# Altium schematic sheet High Voltage.SchDoc("High Voltage")
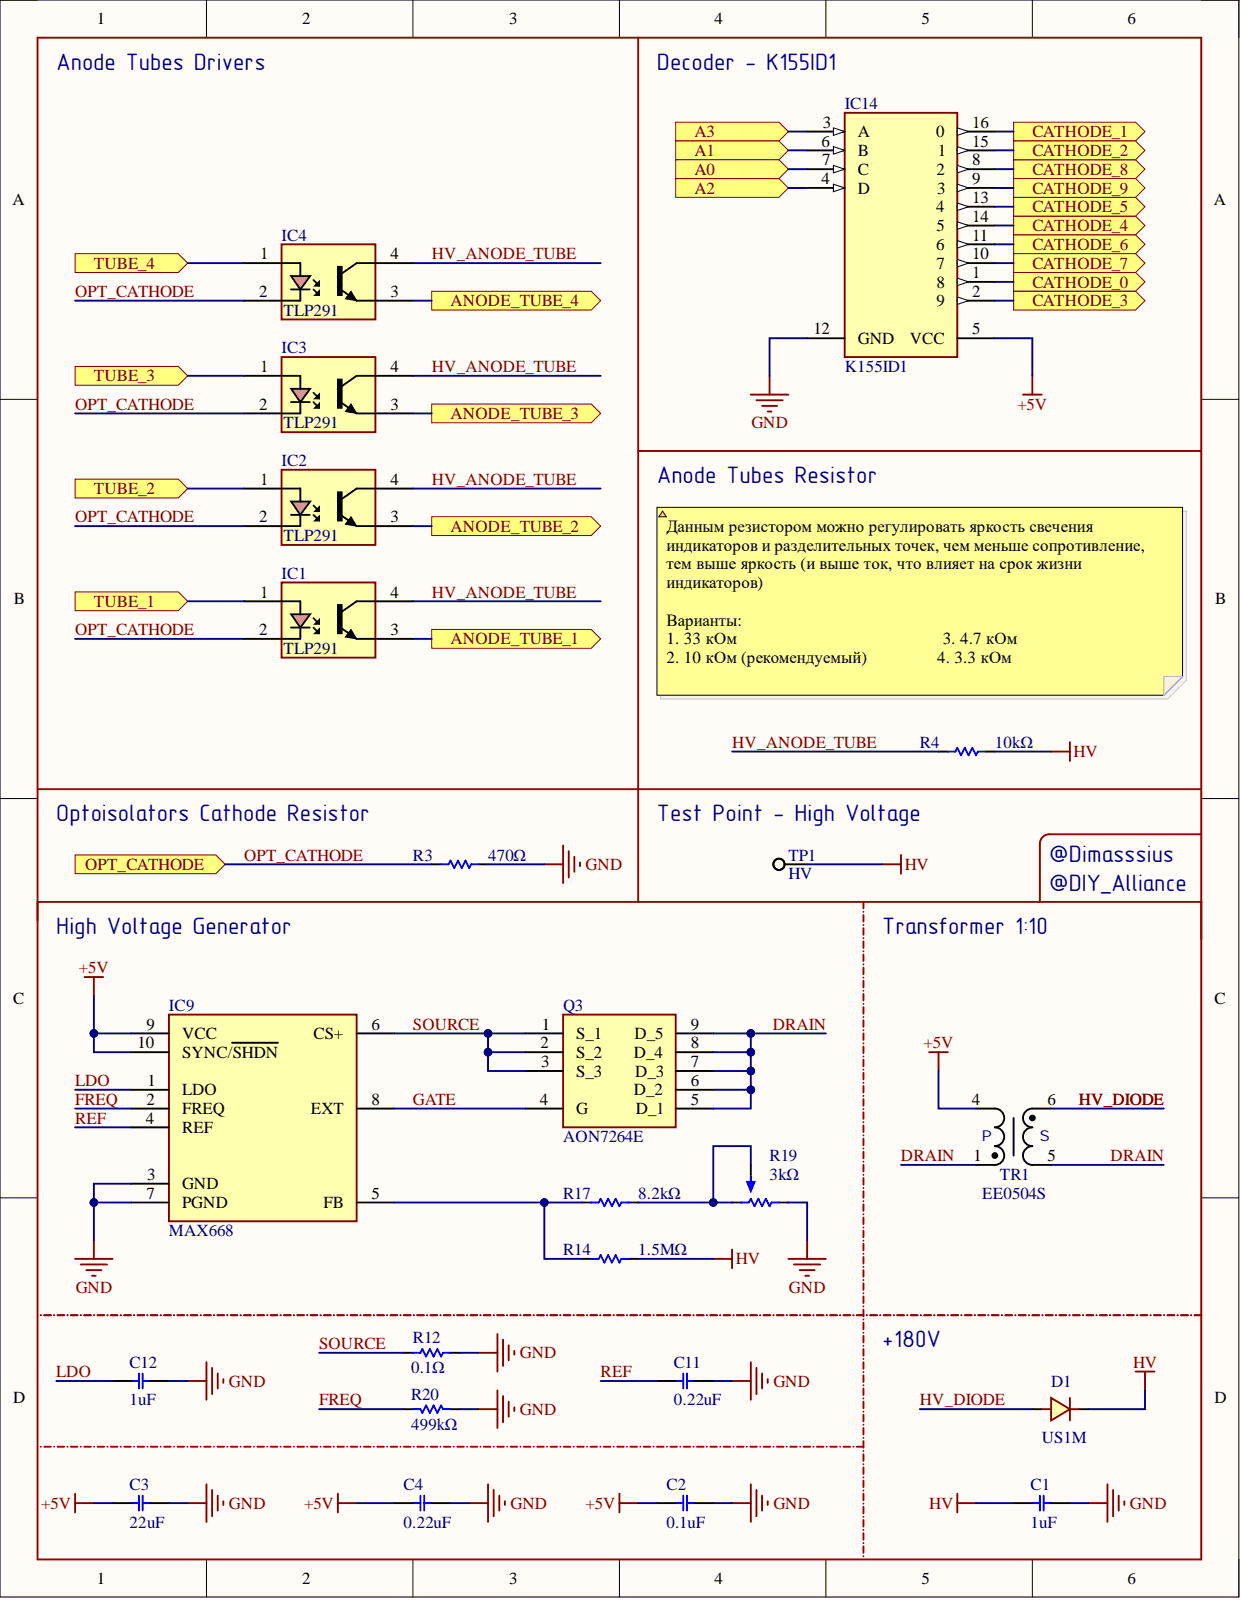
[diagram p0: High Voltage.SchDoc]

## Parts
C1  1uF  [CAP_SMD_MLCC_C1812_(M4532)]
C2  0.1uF  [CAP_SMD_MLCC_C0603_(M1608)_C0402A_(M1005A)]
C3  22uF  [CAP_SMD_MLCC_C0603_(M1608)]
C4  0.22uF  [CAP_SMD_MLCC_C0603_(M1608)_C0402A_(M1005A)]
C11  0.22uF  [CAP_SMD_MLCC_C0603_(M1608)_C0402A_(M1005A)]
C12  1uF  [CAP_SMD_MLCC_C0603_(M1608)_C0402A_(M1005A)]
D1  US1M  [DO-214AC]
IC1  TLP291  [SOIC127P700X230-4N]
IC2  TLP291  [SOIC127P700X230-4N]
IC3  TLP291  [SOIC127P700X230-4N]
IC4  TLP291  [SOIC127P700X230-4N]
IC9  MAX668  [SOP50P490X110-10N]
IC14  K155ID1  [DIP16]
Q3  AON7264E  [DFN-8-EP(3x3)]
R3  470Ω  [RES_SMD_R0603_(M1608)_R0402A_(M1005A)]
R4  10kΩ  [RES_SMD_R0603_(M1608)_R0402A_(M1005A)]
R12  0.1Ω  [RES_SMD_R1206_(M3216)]
R14  1.5MΩ  [RES_SMD_R0603_(M1608)_R0402A_(M1005A)]
R17  8.2kΩ  [RES_SMD_R0603_(M1608)_R0402A_(M1005A)]
R19  3kΩ  [TC33X]
R20  499kΩ  [RES_SMD_R0603_(M1608)_R0402A_(M1005A)]
TP1  HV  [Test Point]  (no BOM)
TR1  EE0504S  [EE0504S]

## Ports
A0, A1, A2, A3, ANODE_TUBE_1, ANODE_TUBE_2, ANODE_TUBE_3, ANODE_TUBE_4, CATHODE_0, CATHODE_1, CATHODE_2, CATHODE_3, CATHODE_4, CATHODE_5, CATHODE_6, CATHODE_7, CATHODE_8, CATHODE_9, OPT_CATHODE, TUBE_1, TUBE_2, TUBE_3, TUBE_4

## Nets
+5V: C2.1 C3.1 C4.2 IC9.9(VCC) IC9.10(SYNC/SHDN) IC14.5(VCC) TR1.4
GND: C1.1 C2.2 C3.2 C4.1 C11.1 C12.2 IC9.3(GND) IC9.7(PGND) IC14.12(GND) R3.1 R12.1 R19.3 R20.2
HV: C1.2 D1.1 R4.2 R14.1 TP1.1
A0: IC14.7(C) ; port A0
A1: IC14.6(B) ; port A1
A2: IC14.4(D) ; port A2
A3: IC14.3(A) ; port A3
ANODE_TUBE_1: IC1.3 ; port ANODE_TUBE_1
ANODE_TUBE_2: IC2.3 ; port ANODE_TUBE_2
ANODE_TUBE_3: IC3.3 ; port ANODE_TUBE_3
ANODE_TUBE_4: IC4.3 ; port ANODE_TUBE_4
CATHODE_0: IC14.1 ; port CATHODE_0
CATHODE_1: IC14.16 ; port CATHODE_1
CATHODE_2: IC14.15 ; port CATHODE_2
CATHODE_3: IC14.2 ; port CATHODE_3
CATHODE_4: IC14.14 ; port CATHODE_4
CATHODE_5: IC14.13 ; port CATHODE_5
CATHODE_6: IC14.11 ; port CATHODE_6
CATHODE_7: IC14.10 ; port CATHODE_7
CATHODE_8: IC14.8 ; port CATHODE_8
CATHODE_9: IC14.9 ; port CATHODE_9
DRAIN: Q3.5(D_1) Q3.6(D_2) Q3.7(D_3) Q3.8(D_4) Q3.9(D_5) TR1.1 TR1.5
FREQ: IC9.2(FREQ) R20.1
GATE: IC9.8(EXT) Q3.4(G)
HV_ANODE_TUBE: IC1.4 IC2.4 IC3.4 IC4.4 R4.1
HV_DIODE: D1.2 TR1.6
LDO: C12.1 IC9.1(LDO)
OPT_CATHODE: IC1.2 IC2.2 IC3.2 IC4.2 R3.2 ; port OPT_CATHODE
REF: C11.2 IC9.4(REF)
SOURCE: IC9.6(CS+) Q3.1(S_1) Q3.2(S_2) Q3.3(S_3) R12.2
TUBE_1: IC1.1 ; port TUBE_1
TUBE_2: IC2.1 ; port TUBE_2
TUBE_3: IC3.1 ; port TUBE_3
TUBE_4: IC4.1 ; port TUBE_4
NetIC9_5: IC9.5(FB) R14.2 R17.2
NetR17_1: R17.1 R19.1 R19.2

## Library data of the parts
C1 = CAP_SMD_MLCC_1UF_250V: Capacitor, Ceramic (MLCC), SMD, 1uF, 250V, ±10%, X7R, Multilayer Ceramic Capacitors MLCC - SMD/SMT, ROHS; Manufacturer: Murata Electronics North America; Part Number: GRM319R61A105KA01D; @ qty: 0; Minimum Quantity: 1; Temperature Coefficient: X5R; Unit Price (USD): 0.28; Voltage: 10V; Applications: General Purpose; Component Kind: Standard; Datasheets: http://www.murata.com/~/media/webrenewal/support/library/catalog/products/capacitor/mlcc/c02e.pdf; Digi-Key Part Number: 490-11607-1-ND; Factory Stock: 0; Features: -; Height - Seated (Max): -; Image: //media.digikey.com/Renders/Murata%20Renders/GR331BD72E473KW01L.jpg; Lead Spacing: -; Lead Style: -; Library Name: Capacitors.SchLib; Mounting Type: Surface Mount, MLCC; Operating Temperature: -55°C ~ 85°C; Package / Case: -; Packaging: Cut Tape (CT); Part Status: Active; Quantity Available: 3593; Ratings: -; Series: GRM; Size / Dimension: -; Thickness (Max): -; Tolerance: ±10%; Value: 1uF
C2 = CAP_SMD_MLCC_0.1UF_6.3V: Capacitor, Ceramic (MLCC), SMD, 100nF, 6.3V, ±10%, X7R, Multilayer Ceramic Capacitors MLCC - SMD/SMT, ROHS; Manufacturer: Murata Electronics North America; Part Number: LLM315R71C104MA11L; @ qty: 0; Minimum Quantity: 1; Tolerance: ±20%; Unit Price (USD): 0.9; Voltage: 16V; Applications: Bypass, Decoupling; Component Kind: Standard; Datasheets: http://www.murata.com/~/media/webrenewal/support/library/catalog/products/capacitor/mlcc/c02e.pdf; Digi-Key Part Number: 490-4495-1-ND; Factory Stock: 0; Features: Low ESL (Multi-Terminal); Height - Seated (Max): -; Image: //media.digikey.com/Renders/Murata%20Renders/1206(LLM315).jpg; Lead Spacing: -; Lead Style: -; Library Name: Capacitors.SchLib; Mounting Type: Surface Mount, MLCC; Operating Temperature: -55°C ~ 125°C; Package / Case: -; Packaging: Cut Tape (CT); Part Status: Active; Quantity Available: 2915; Ratings: -; Series: LLM; Size / Dimension: -; Temperature Coefficient: X7R; Thickness (Max): -; Value: 0.1uF
C3 = CAP_SMD_MLCC_22UF_6.3V: Capacitor, Ceramic (MLCC), SMD, 22uF, 6.3V, ±20%, X5R, Multilayer Ceramic Capacitors MLCC - SMD/SMT, ROHS; Manufacturer: Murata Electronics North America; Part Number: GRM31CR60J226KE19L; @ qty: 0; Minimum Quantity: 1; Tolerance: ±10%; Unit Price (USD): 0.35; Applications: General Purpose; Component Kind: Standard; Datasheets: http://www.murata.com/~/media/webrenewal/support/library/catalog/products/capacitor/mlcc/c02e.pdf; Digi-Key Part Number: 490-1824-1-ND; Factory Stock: 0; Features: -; Height - Seated (Max): -; Image: //media.digikey.com/Renders/Murata%20Renders/GR331BD72E473KW01L.jpg; Lead Spacing: -; Lead Style: -; Library Name: Capacitors.SchLib; Mounting Type: Surface Mount, MLCC; Operating Temperature: -55°C ~ 85°C; Package / Case: -; Packaging: Cut Tape (CT); Part Status: Active; Quantity Available: 42035; Ratings: -; Series: GRM; Size / Dimension: -; Temperature Coefficient: X5R; Thickness (Max): -; Value: 22uF; Voltage: 6.3V
C4 C11 = CAP_SMD_MLCC_0.22UF_6.3V: Capacitor, Ceramic (MLCC), SMD, 220nF, 6.3V, ±10%, X7R, Multilayer Ceramic Capacitors MLCC - SMD/SMT, ROHS; Manufacturer: Murata Electronics North America; Part Number: GRM319R71C224KA01D; @ qty: 0; Minimum Quantity: 1; Unit Price (USD): 0.26; Voltage: 16V; Applications: General Purpose; Component Kind: Standard; Datasheets: http://www.murata.com/~/media/webrenewal/support/library/catalog/products/capacitor/mlcc/c02e.pdf; Digi-Key Part Number: 490-11608-1-ND; Factory Stock: 0; Features: -; Height - Seated (Max): -; Image: //media.digikey.com/Renders/Murata%20Renders/GR331BD72E473KW01L.jpg; Lead Spacing: -; Lead Style: -; Library Name: Capacitors.SchLib; Mounting Type: Surface Mount, MLCC; Operating Temperature: -55°C ~ 125°C; Package / Case: -; Packaging: Cut Tape (CT); Part Status: Active; Quantity Available: 10161; Ratings: -; Series: GRM; Size / Dimension: -; Temperature Coefficient: X7R; Thickness (Max): -; Tolerance: ±10%; Value: 0.22uF
C12 = CAP_SMD_MLCC_1UF_6.3V: Capacitor, Ceramic (MLCC), SMD, 1uF, 6.3V, ±10%, X5R, Multilayer Ceramic Capacitors MLCC - SMD/SMT, ROHS; Manufacturer: Murata Electronics North America; Part Number: GRM319R61A105KA01D; @ qty: 0; Minimum Quantity: 1; Unit Price (USD): 0.28; Voltage: 10V; Applications: General Purpose; Component Kind: Standard; Datasheets: http://www.murata.com/~/media/webrenewal/support/library/catalog/products/capacitor/mlcc/c02e.pdf; Digi-Key Part Number: 490-11607-1-ND; Factory Stock: 0; Features: -; Height - Seated (Max): -; Image: //media.digikey.com/Renders/Murata%20Renders/GR331BD72E473KW01L.jpg; Lead Spacing: -; Lead Style: -; Library Name: Capacitors.SchLib; Mounting Type: Surface Mount, MLCC; Operating Temperature: -55°C ~ 85°C; Package / Case: -; Packaging: Cut Tape (CT); Part Status: Active; Quantity Available: 3593; Ratings: -; Series: GRM; Size / Dimension: -; Temperature Coefficient: X5R; Thickness (Max): -; Tolerance: ±10%; Value: 1uF
D1 = US1M: Diode, SMD, SMA(DO-214AC), 1kV, 1A, 75ns, 1.65V@1A, Independent Type, SMA, Fast Recovery / High Efficiency Diodes, ROHS; Component Kind: Standard; Datasheet Link: https://componentsearchengine.com/Datasheets/2/US1M-E3_61T.pdf; Height: 2.29mm; Library Name: SamacSys.SchLib; Value: US1M
IC1-IC4 = TLP291: Photovoltaic Output Optoisolator, SOP-4-1.27mm, 80V, 3.75kV, 50mA, 300mV@2.4mA, 8mA, 1, 5V, 1.25V, DC  Transistor, Photovoltaic Output Optoisolators, ROHS; Component Kind: Standard; Datasheet Link: https://datasheet.datasheetarchive.com/originals/distributors/Datasheets-TOS/DSATOSJO10001087.pdf; Height: 2.3mm; Library Name: SamacSys.SchLib; Value: TLP291
IC9 = MAX668EUB: AC-DC Controller and Regulator, MSOP-10, 100kHz~500kHz, 3V~28V, AC-DC Controllers and Regulators, ROHS; Component Kind: Standard; Datasheet Link: http://datasheets.maximintegrated.com/en/ds/MAX668-MAX669.pdf; Height: 1.1mm; Library Name: SamacSys.SchLib; Value: MAX668
IC14 = K155ID1: Decoder, DIP-16, 5V±5%, 25mA, 150ns, High Voltage Output, BCD to Decimal Decoders, RoHS; Component Kind: Standard; Datasheet Link: http://pdf1.alldatasheet.com/datasheet-pdf/view/115763/TI/SN74141.html; Height: 5.08mm; Library Name: SamacSys.SchLib; Value: K155ID1
Q3 = AON7264E: MOSFET (N-Channel), DFN-8(3x3), 60V, 28A, 11W, 13.3mΩ@4.5V, 28A, 1.8V, 1 N-channel, MOSFETs, ROHS; Component Kind: Standard; Library Name: SamacSys.SchLib; Value: AON7264E
R3 = RES_SMD_470Ω: Resistor, Thick Film Resistor, SMD, 470Ω, ±5%, Chip Resistor - Surface Mount, ROHS; Component Kind: Standard; Library Name: Resistors.SchLib; Value: 470Ω
R4 = RES_SMD_10KΩ: Resistor, Thick Film Resistor, SMD, 10kΩ, ±5%, Chip Resistor - Surface Mount, ROHS; Component Kind: Standard; Library Name: Resistors.SchLib; Value: 10kΩ
R12 = RES_SMD_0.1Ω: Resistor, Thick Film Resistor, SMD, 0.1Ω, ±5%, Chip Resistor - Surface Mount, ROHS; Component Kind: Standard; Library Name: Resistors.SchLib; Value: 0.1Ω
R14 = RES_SMD_1.5MΩ: Resistor, Thick Film Resistor, SMD, 1.5MΩ, ±5%, Chip Resistor - Surface Mount, ROHS; Component Kind: Standard; Library Name: Resistors.SchLib; Value: 1.5MΩ
R17 = RES_SMD_8.2KΩ: Resistor, Thick Film Resistor, SMD, 8.2kΩ, ±5%, Chip Resistor - Surface Mount, ROHS; Component Kind: Standard; Library Name: Resistors.SchLib; Value: 8.2kΩ
R19 = RES_SMD_POT_3KΩ: Resistor, Potentiometer, SMD, SMD-3P, 3.9x3.1mm, Potentiometer, 3kΩ, ±25%, 150mW, Potentiometers, Variable Resistors, ROHS; Component Kind: Standard; Library Name: Resistors.SchLib; Value: 3kΩ
R20 = RES_SMD_499KΩ: Resistor, Thick Film Resistor, SMD, 499kΩ, ±5%, Chip Resistor - Surface Mount, ROHS; Component Kind: Standard; Library Name: Resistors.SchLib; Value: 499kΩ
TP1 = Test Point: Description: Test Point; Library Name: SamacSys.SchLib; Value: HV
TR1 = EE0504S: Power Transformer, SMD-5P, 1:10, 6.4x6.4mm, Power Transformers, ROHS; Component Kind: Standard; Library Name: SamacSys.SchLib; Value: EE0504S
